# Altium schematic sheet Output.SchDoc
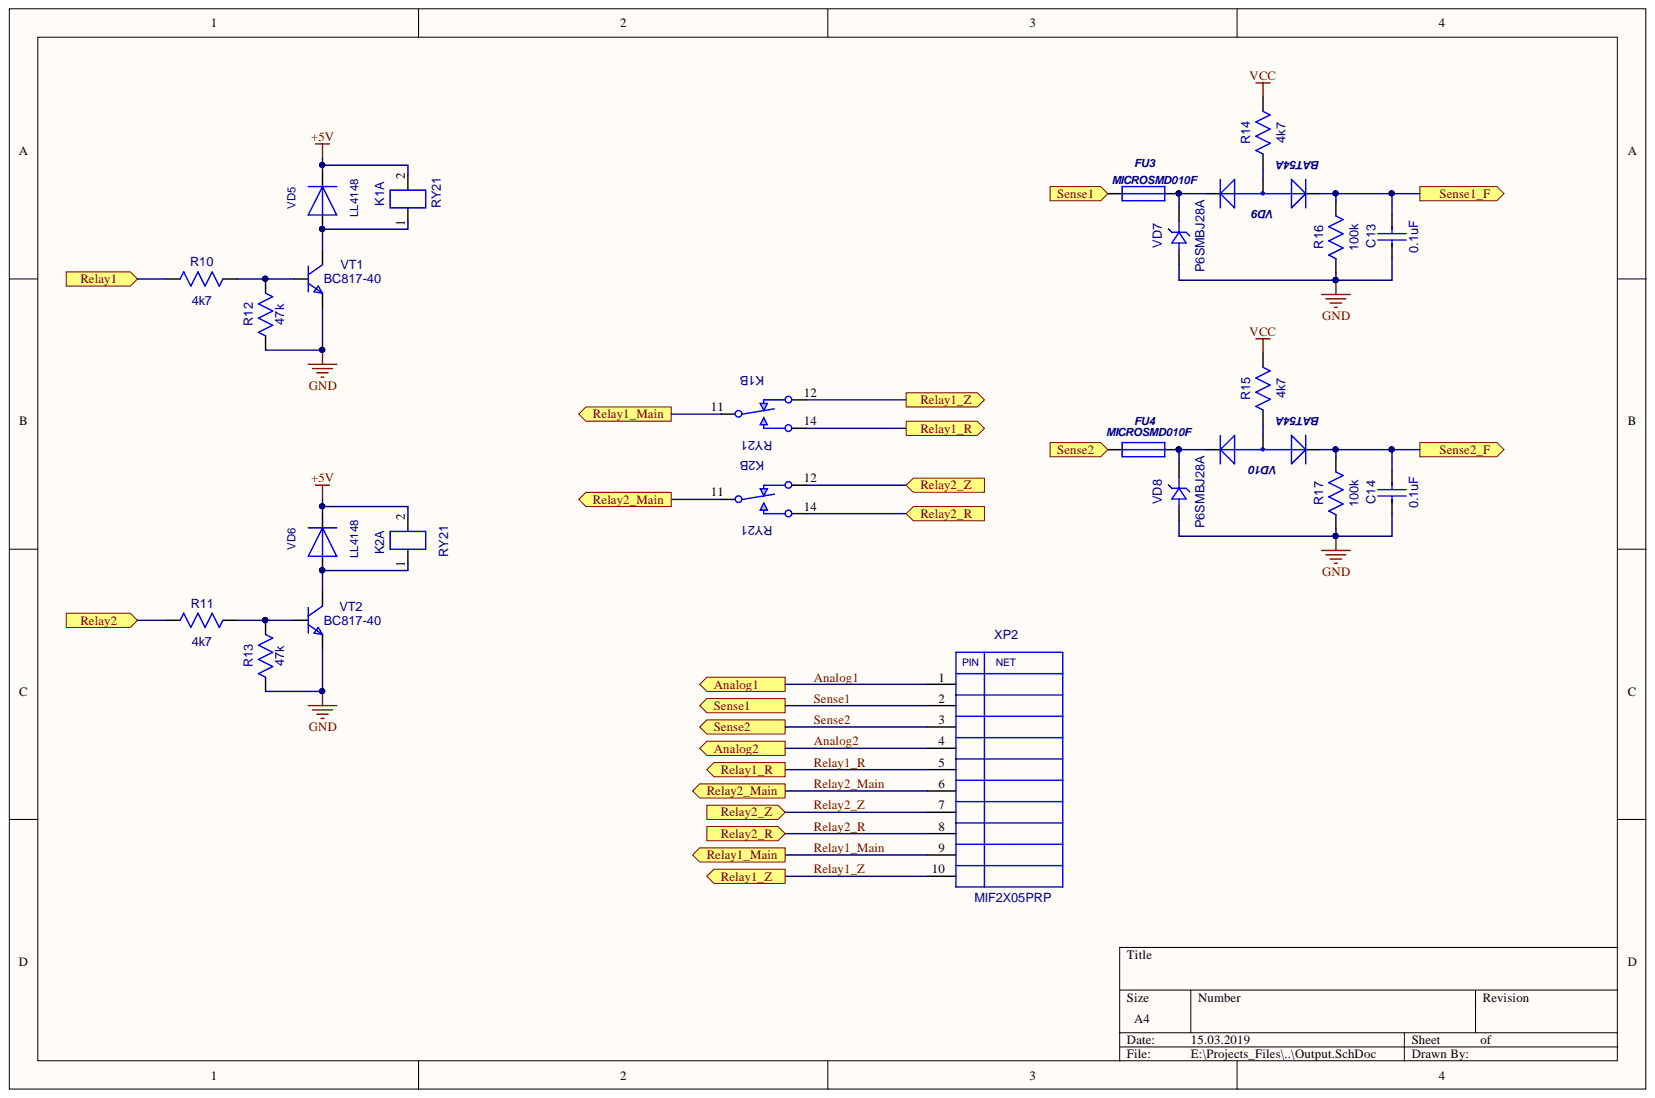
[diagram p0: Output.SchDoc]

## Parts
C13  0.1uF  [CC0603-3M]
C14  0.1uF  [CC0603-3M]
FU3  MICROSMD010F  [RC1210]
FU4  MICROSMD010F  [RC1210]
K1  RY21  [RY21_RY21(Primary)]  (parts A,B)
K2  RY21  [RY21_RY21(Primary)]  (parts A,B)
R10  4k7  [RC0603-3M]
R11  4k7  [RC0603-3M]
R12  47k  [RC0603-3M]
R13  47k  [RC0603-3M]
R14  4k7  [RC0603-3M]
R15  4k7  [RC0603-3M]
R16  100k  [RC0603-3M]
R17  100k  [RC0603-3M]
VD5  LL4148  [SOD-80]
VD6  LL4148  [SOD-80]
VD7  P6SMBJ28A  [SMB]
VD8  P6SMBJ28A  [SMB]
VD9  BAT54A  [SOT-23(123)]
VD10  BAT54A  [SOT-23(123)]
VT1  BC817-40  [SOT-23(123)]
VT2  BC817-40  [SOT-23(123)]
XP2  MIF2X05PRP  [MIF2X05PRP]

## Ports
Analog1, Analog2, Relay1, Relay1_Main, Relay1_R, Relay1_Z, Relay2, Relay2_Main, Relay2_R, Relay2_Z, Sense1, Sense1_F, Sense2, Sense2_F

## Nets
+5V: K1.2 K2.2 VD5.2 VD6.2
GND: C13.1 C14.1 R12.1 R13.1 R16.1 R17.1 VD7.1 VD8.1 VT1.2 VT2.2
VCC: R14.2 R15.2
Analog1: XP2.1 ; port Analog1
Analog2: XP2.4 ; port Analog2
Relay1: R10.1 ; port Relay1
Relay1_Main: K1.11 XP2.9 ; port Relay1_Main
Relay1_R: K1.14 XP2.5 ; port Relay1_R
Relay1_Z: K1.12 XP2.10 ; port Relay1_Z
Relay2: R11.1 ; port Relay2
Relay2_Main: K2.11 XP2.6 ; port Relay2_Main
Relay2_R: K2.14 XP2.8 ; port Relay2_R
Relay2_Z: K2.12 XP2.7 ; port Relay2_Z
Sense1: FU3.1 XP2.2 ; port Sense1
Sense1_F: C13.2 R16.2 VD9.1 ; port Sense1_F
Sense2: FU4.1 XP2.3 ; port Sense2
Sense2_F: C14.2 R17.2 VD10.1 ; port Sense2_F
NetFU3_2: FU3.2 VD7.2 VD9.2
NetFU4_2: FU4.2 VD8.2 VD10.2
NetK1_1: K1.1 VD5.1 VT1.3
NetK2_1: K2.1 VD6.1 VT2.3
NetR10_2: R10.2 R12.2 VT1.1
NetR11_2: R11.2 R13.2 VT2.1
NetR14_1: R14.1 VD9.3
NetR15_1: R15.1 VD10.3

## Library data of the parts
C13 C14 = CC0603: Component Kind: Standard; Description: CC0603; Value: 0.1uF; Library Name: gprs_modem.SchLib
FU3 FU4 = MICROSMD: Component Kind: Standard; Description: MICROSMD; Value: MICROSMD010F; Library Name: gprs_modem.SchLib
R10-R17 = RC0603: Component Kind: Standard; Description: RC0603; Library Name: gprs_modem.SchLib
  R10 R11 R14 R15: Value: 4k7
  R12 R13: Value: 47k
  R16 R17: Value: 100k
VD7 VD8 = SMBJ: Component Kind: Standard; Description: SMBJ; Value: P6SMBJ28A; Library Name: gprs_modem.SchLib
VD9 VD10 = BAT54A: 1.0 Ampere General Purpose Rectifier; ComponentField6: Published 8-Jun-2000, Modified 11-July-2000: SIM Model Added.; Component Kind: Standard; Simulation: DIODE; Library Name: gprs_modem.SchLib
VT1 VT2 = BC846BLT1: ComponentField7: Published 29-Sep-2000; Value: {Value}; Component Kind: Standard; Description: BC846BLT1; OriginalName: BC846BLT1; Simulation: BC846BLT1; Library Name: gprs_modem_2.SchLib
K1 K2 = RY21: Component Kind: Standard; Description: RY21; Library Name: shlagbaum.SchLib
VD5 VD6 = LL4148: Component Kind: Standard; Description: LL4148; Library Name: gprs_modem_2.SchLib
XP2 = MIF2X05PRP: Component Kind: Standard; Description: MIF2X05PRP; Library Name: shlagbaum.SchLib
